# Altium schematic sheet Sheet1.SchDoc
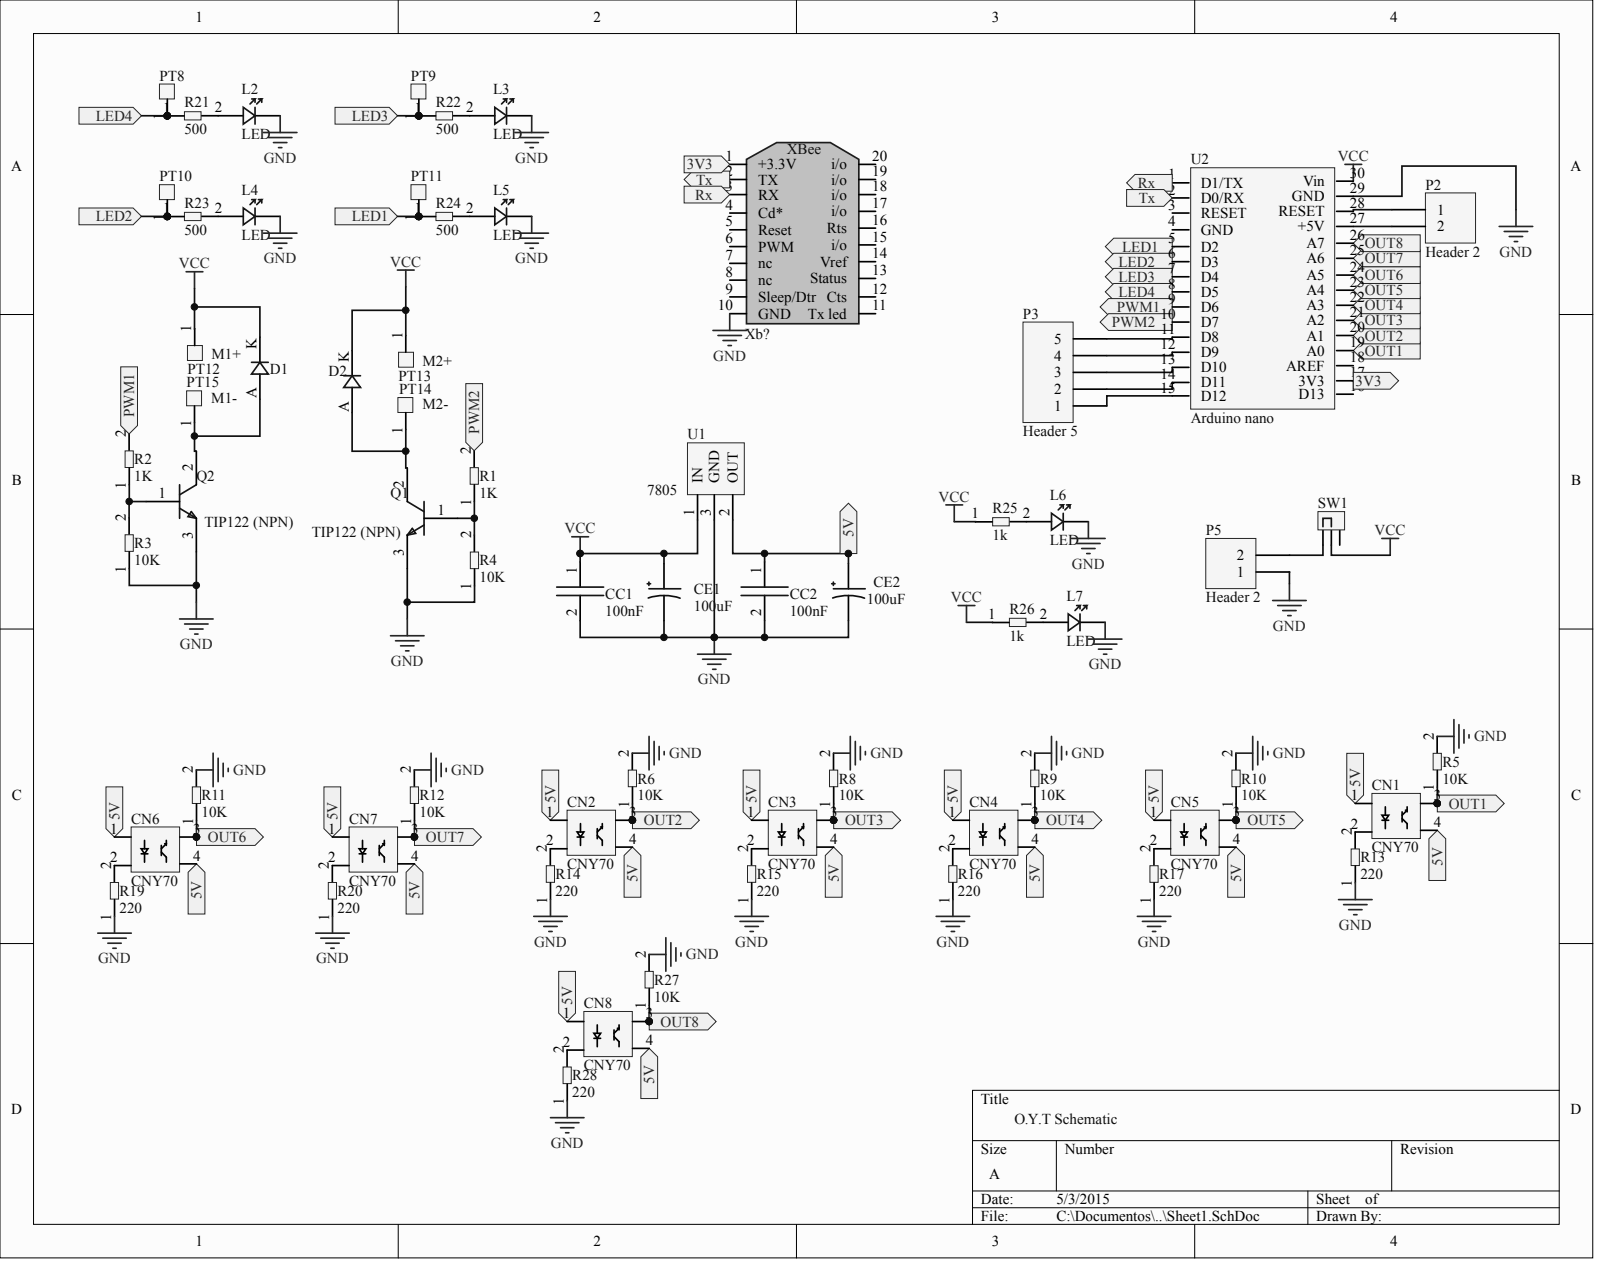
[diagram p0: Sheet1.SchDoc]

## Parts
CC1  100nF
CC2  100nF
CE1  100uF
CE2  100uF
CN1  CNY70
CN2  CNY70
CN3  CNY70
CN4  CNY70
CN5  CNY70
CN6  CNY70
CN7  CNY70
CN8  CNY70
D1  DIODO  (value not drawn)
D2  DIODO  (value not drawn)
L2  LED
L3  LED
L4  LED
L5  LED
L6  LED
L7  LED
P2  Header 2
P3  Header 5
P5  Header 2
PT8  Pino de Testes  (value not drawn)
PT9  Pino de Testes  (value not drawn)
PT10  Pino de Testes  (value not drawn)
PT11  Pino de Testes  (value not drawn)
PT12  M1+
PT13  M2+
PT14  M2-
PT15  M1-
Q1  TIP122 (NPN)
Q2  TIP122 (NPN)
R1  1K
R2  1K
R3  10K
R4  10K
R5  10K
R6  10K
R8  10K
R9  10K
R10  10K
R11  10K
R12  10K
R13  220
R14  220
R15  220
R16  220
R17  220
R19  220
R20  220
R21  500
R22  500
R23  500
R24  500
R25  1k
R26  1k
R27  10K
R28  220
SW1  Slide Switch  (value not drawn)
U1  7805
U2  Arduino nano
Xb?  XBee

## Ports
3V3, 5V, LED1, LED2, LED3, LED4, OUT1, OUT2, OUT3, OUT4, OUT5, OUT6, OUT7, OUT8, PWM1, PWM2, RX, TX

## Nets
GND: CC1.2 CC2.2 CE1.1 CE2.1 L2.K L3.K L4.K L5.K L6.K L7.K P5.1 Q1.3 Q2.3 R3.1 R4.1 R5.2 R6.2 R8.2 R9.2 R10.2 R11.2 R12.2 R13.1 R14.1 R15.1 R16.1 R17.1 R19.1 R20.1 R27.2 R28.1 U1.3 U2.29(GND) Xb?.10(GND)
VCC: CC1.1 CE1.2 D1.K D2.K PT12.1 PT13.1 R25.1 R26.1 SW1.2 U1.1 U2.30(Vin)
3V3: U2.17(3V3) Xb?.1(+3.3V) ; port 3V3
5V: CC2.1 CE2.2 CN1.1 CN1.4 CN2.1 CN2.4 CN3.1 CN3.4 CN4.1 CN4.4 CN5.1 CN5.4 CN6.1 CN6.4 CN7.1 CN7.4 CN8.1 CN8.4 U1.2 ; port 5V
LED1: PT11.1 R24.1 U2.5(D2) ; port LED1
LED2: PT10.1 R23.1 U2.6(D3) ; port LED2
LED3: PT9.1 R22.1 U2.7(D4) ; port LED3
LED4: PT8.1 R21.1 U2.8(D5) ; port LED4
OUT1: CN1.3 R5.1 U2.19(A0) ; port OUT1
OUT2: CN2.3 R6.1 U2.20(A1) ; port OUT2
OUT3: CN3.3 R8.1 U2.21(A2) ; port OUT3
OUT4: CN4.3 R9.1 U2.22(A3) ; port OUT4
OUT5: CN5.3 R10.1 U2.23(A4) ; port OUT5
OUT6: CN6.3 R11.1 U2.24(A5) ; port OUT6
OUT7: CN7.3 R12.1 U2.25(A6) ; port OUT7
OUT8: CN8.3 R27.1 U2.26(A7) ; port OUT8
PWM1: R2.2 U2.9(D6) ; port PWM1
PWM2: R1.2 U2.10(D7) ; port PWM2
NetCN1_2: CN1.2 R13.2
NetCN2_2: CN2.2 R14.2
NetCN3_2: CN3.2 R15.2
NetCN4_2: CN4.2 R16.2
NetCN5_2: CN5.2 R17.2
NetCN6_2: CN6.2 R19.2
NetCN7_2: CN7.2 R20.2
NetCN8_2: CN8.2 R28.2
NetD1_A: D1.A PT15.1 Q2.2
NetD2_A: D2.A PT14.1 Q1.2
NetL2_A: L2.A R21.2
NetL3_A: L3.A R22.2
NetL4_A: L4.A R23.2
NetL5_A: L5.A R24.2
NetL6_A: L6.A R25.2
NetL7_A: L7.A R26.2
NetP2_1: P2.1 U2.28(RESET)
NetP2_2: P2.2 U2.27(+5V)
NetP3_1: P3.1 U2.15(D12)
NetP3_2: P3.2 U2.14(D11)
NetP3_3: P3.3 U2.13(D10)
NetP3_4: P3.4 U2.12(D9)
NetP3_5: P3.5 U2.11(D8)
NetP5_2: P5.2 SW1.1
NetQ1_1: Q1.1 R1.1 R4.2
NetQ2_1: Q2.1 R2.1 R3.2
NetU2_1: U2.1(D1/TX) Xb?.3(RX) ; port RX
NetU2_2: U2.2(D0/RX) Xb?.2(TX) ; port TX

Not connected: SW1 3; U2 3(RESET) 4(GND) 16(D13) 18(AREF); Xb? 4(Cd*) 5(Reset) 6(PWM) 7(nc) 8(nc) 9(Sleep/Dtr) 11(Tx_led) 12(Cts) 13(Status) 14(Vref) 15(i/o) 16(Rts) 17(i/o) 18(i/o) 19(i/o) 20(i/o)

## Library data of the parts
CC1 CC2 CE1 CE2 CN1-CN8 D1 D2 L2-L7 P2 P3 P5 PT8-PT15 Q1 Q2 R1-R6 R8-R17 R19-R28 SW1 U1 U2 Xb? = (no symbol name)
  P2 P3 P5: LatestRevisionDate: 17-Jul-2002; LatestRevisionNote: Re-released for DXP Platform.; Publisher: Altium Limited
